# Altium schematic sheet MiniRoverShield.SchDoc
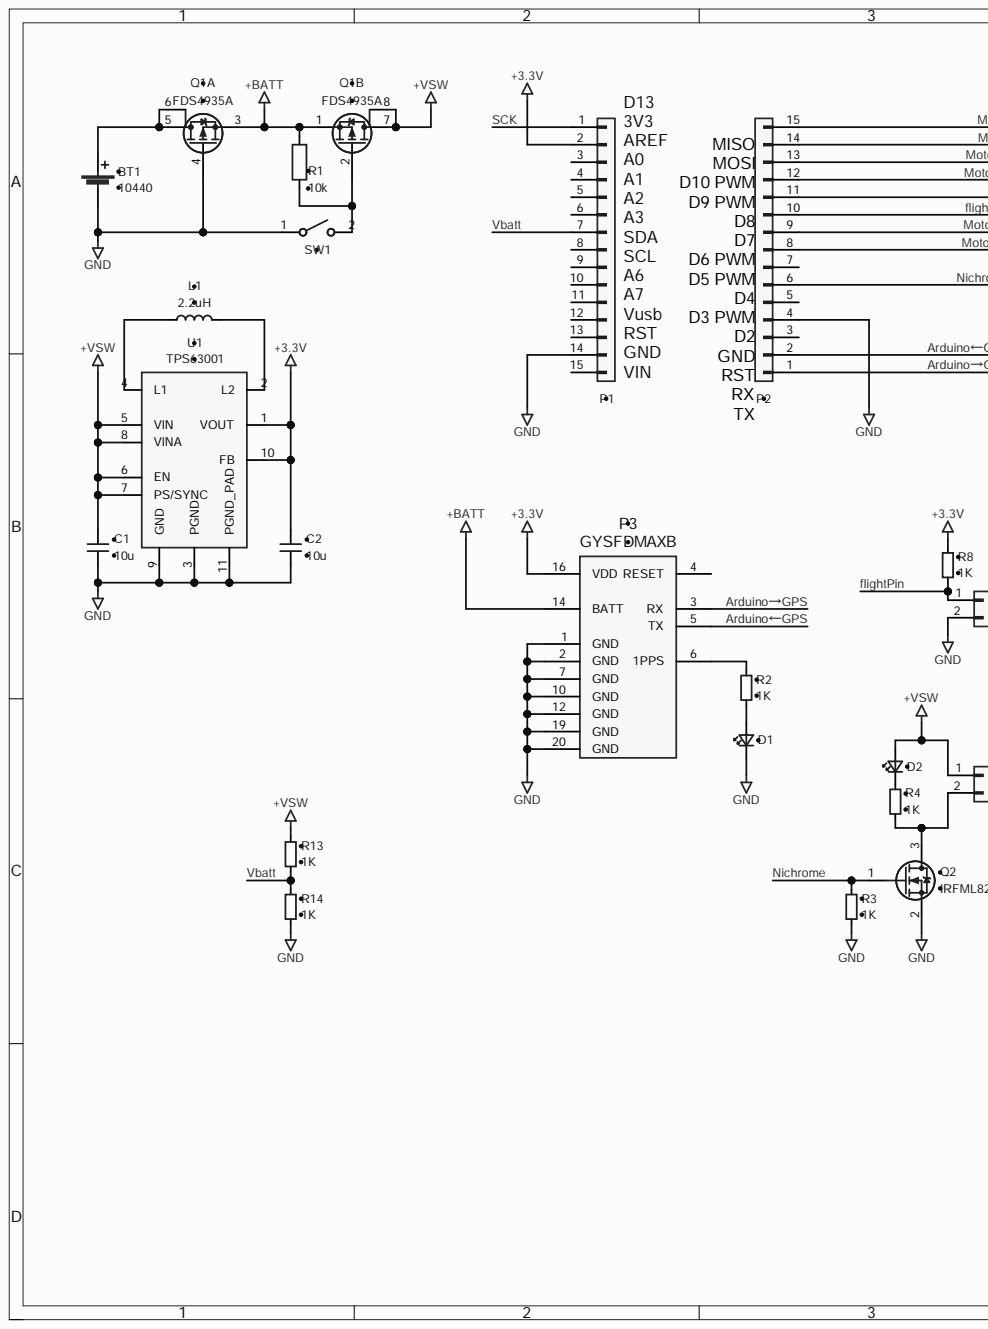
[diagram p0: MiniRoverShield.SchDoc, left]
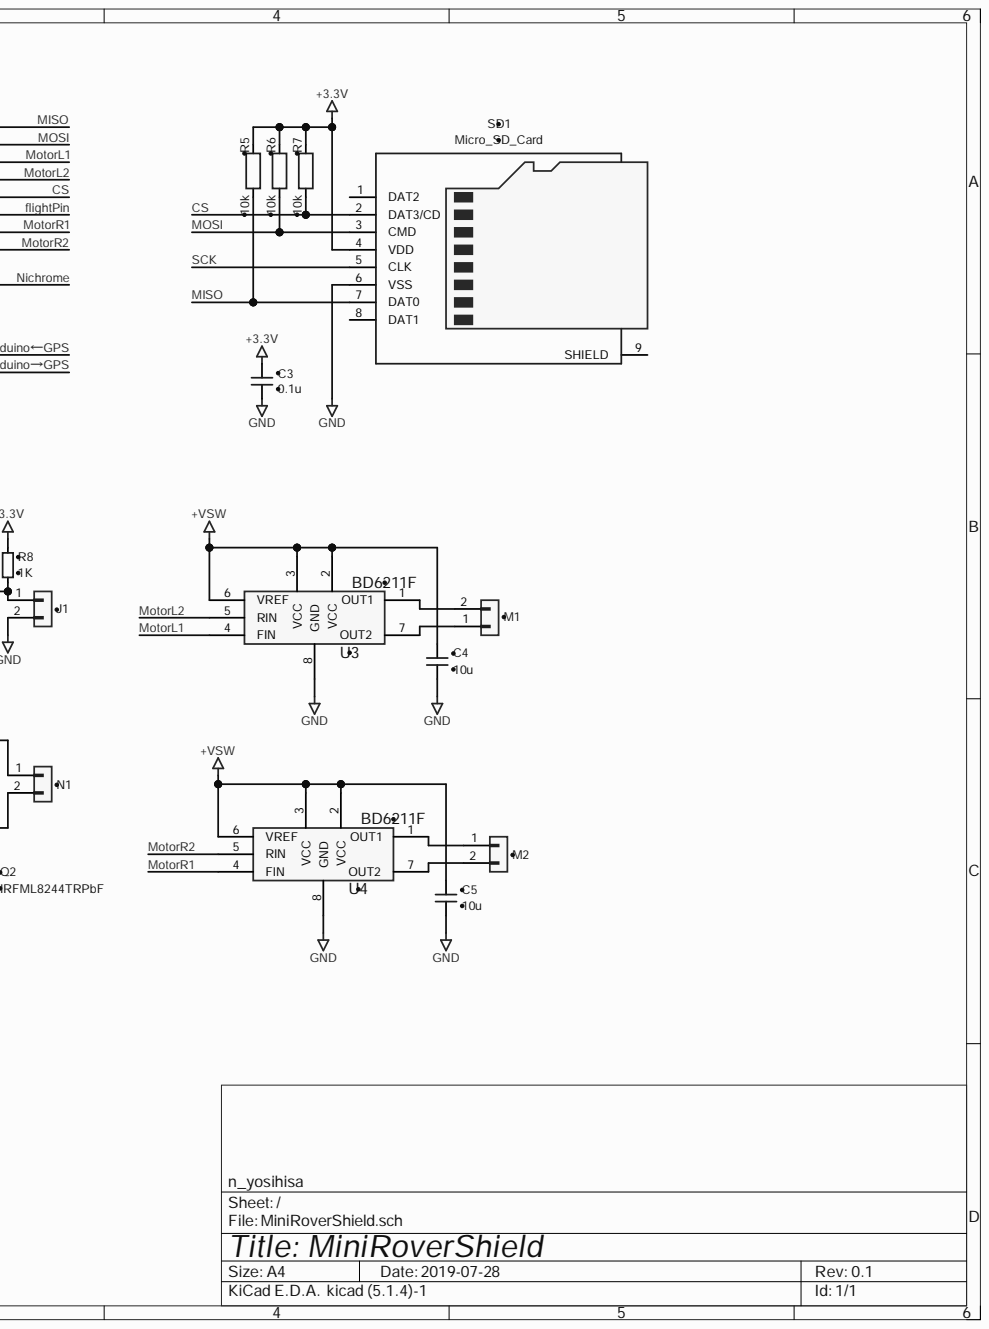
[diagram p1: MiniRoverShield.SchDoc, right]

## Parts
BT1  10440  [user:BC-0402_AAA]
C1  10u  [Capacitor_SMD:C_0805_2012Metric_Pad1.15x1.40mm_HandSolder]
C2  10u  [Capacitor_SMD:C_0805_2012Metric_Pad1.15x1.40mm_HandSolder]
C3  0.1u  [Capacitor_SMD:C_0603_1608Metric_Pad1.05x0.95mm_HandSolder]
C4  10u  [Capacitor_SMD:C_0805_2012Metric_Pad1.15x1.40mm_HandSolder]
C5  10u  [Capacitor_SMD:C_0805_2012Metric_Pad1.15x1.40mm_HandSolder]
D1  RED  [LED_SMD:LED_0603_1608Metric_Castellated]  (value not drawn)
D2  RED  [LED_SMD:LED_0603_1608Metric_Castellated]  (value not drawn)
J1  flightPin  [Connector_PinHeader_2.54mm:PinHeader_1x02_P2.54mm_Horizontal]
L1  2.2uH  [user_library:TDK_VLS3012]
M1  Motor  [Connector_JST:JST_EH_B02B-EH-A_1x02_P2.50mm_Vertical]  (value not drawn)
M2  Motor  [Connector_JST:JST_EH_B02B-EH-A_1x02_P2.50mm_Vertical]  (value not drawn)
N1  Nichrome  [user:MPT0.5_2-2.54]
P1  Arduino nano 33  [Connector_PinSocket_2.54mm:PinSocket_1x15_P2.54mm_Vertical]  (value not drawn)
P2  Arduino nano 33  [Connector_PinSocket_2.54mm:PinSocket_1x15_P2.54mm_Vertical]  (value not drawn)
P3  GYSFDMAXB  [user:GYSFDMAXB]
Q1  FDS4935A  [Package_SO:SOIC-8_3.9x4.9mm_P1.27mm]  (parts A,B)
Q2  IRFML8244TRPbF  [Package_TO_SOT_SMD:SOT-23]
R1  10k  [Resistor_SMD:R_0603_1608Metric_Pad1.05x0.95mm_HandSolder]
R2  1K  [Resistor_SMD:R_0603_1608Metric_Pad1.05x0.95mm_HandSolder]
R3  1K  [Resistor_SMD:R_0603_1608Metric_Pad1.05x0.95mm_HandSolder]
R4  1K  [Resistor_SMD:R_0603_1608Metric_Pad1.05x0.95mm_HandSolder]
R5  10k  [Resistor_SMD:R_0603_1608Metric_Pad1.05x0.95mm_HandSolder]
R6  10k  [Resistor_SMD:R_0603_1608Metric_Pad1.05x0.95mm_HandSolder]
R7  10k  [Resistor_SMD:R_0603_1608Metric_Pad1.05x0.95mm_HandSolder]
R8  1K  [Resistor_SMD:R_0603_1608Metric_Pad1.05x0.95mm_HandSolder]
R13  1K  [Resistor_SMD:R_0603_1608Metric_Pad1.05x0.95mm_HandSolder]
R14  1K  [Resistor_SMD:R_0603_1608Metric_Pad1.05x0.95mm_HandSolder]
SD1  Micro_SD_Card  [Connector_Card:microSD_HC_Hirose_DM3AT-SF-PEJM5]
SW1  Power  [user:SS-12D01-VG4]  (value not drawn)
U1  TPS63001  [Package_SON:Texas_S-PVSON-N10_ThermalVias]
U3  BD6211F  [Package_SO:SOIC-8_3.9x4.9mm_P1.27mm]
U4  BD6211F  [Package_SO:SOIC-8_3.9x4.9mm_P1.27mm]

## Nets
+3.3V: C2.1 C3.1 P1.2 P3.16(VDD) R5.1 R6.1 R7.1 R8.1 SD1.4(VDD) U1.1(VOUT) U1.10(FB)
+BATT: P3.14(BATT) Q1.1 Q1.3 R1.1
+VSW: C1.1 C4.1 C5.1 D2.2 N1.1 Q1.7 Q1.8 R13.1 U1.5(VIN) U1.6(EN) U1.7(PS/SYNC) U1.8(VINA) U3.2(VCC) U3.3(VCC) U3.6(VREF) U4.2(VCC) U4.3(VCC) U4.6(VREF)
GND: BT1.2 C1.2 C2.2 C3.2 C4.2 C5.2 D1.1 J1.2 P1.14 P2.4 P3.1(GND) P3.2(GND) P3.7(GND) P3.10(GND) P3.12(GND) P3.19(GND) P3.20(GND) Q1.4 Q2.2 R3.2 R14.2 SD1.6(VSS) SW1.1 U1.3(PGND) U1.9(GND) U1.11(PGND_PAD) U3.8(GND) U4.8(GND)
Arduino←GPS: P2.2 P3.5(TX)
Arduino→GPS: P2.1 P3.3(RX)
CS: P2.11 R7.2 SD1.2(DAT3/CD)
flightPin: J1.1 P2.10 R8.2
MISO: P2.15 R5.2 SD1.7(DAT0)
MOSI: P2.14 R6.2 SD1.3(CMD)
MotorL1: P2.13 U3.4(FIN)
MotorL2: P2.12 U3.5(RIN)
MotorR1: P2.9 U4.4(FIN)
MotorR2: P2.8 U4.5(RIN)
Nichrome: P2.6 Q2.1 R3.1
SCK: P1.1 SD1.5(CLK)
Vbatt: P1.7 R13.2 R14.1
NetBT1_1: BT1.1 Q1.5 Q1.6
NetD1_2: D1.2 R2.2
NetD2_1: D2.1 R4.1
NetL1_1: L1.1 U1.4(L1)
NetL1_2: L1.2 U1.2(L2)
NetM1_1: M1.1 U3.7(OUT2)
NetM1_2: M1.2 U3.1(OUT1)
NetM2_1: M2.1 U4.1(OUT1)
NetM2_2: M2.2 U4.7(OUT2)
NetN1_2: N1.2 Q2.3 R4.2
NetP3_6: P3.6(1PPS) R2.1
NetQ1_2: Q1.2 R1.2 SW1.2

Not connected: P1 3 4 5 6 8 9 10 11 12 13 15; P2 3 5 7; P3 4(RESET); SD1 1(DAT2) 8(DAT1) 9(SHIELD)

## Library data of the parts
BT1 = Battery_Cell: Component Kind: Standard; Library Name: Device; Value: 10440
C1-C5 = C_Small: Component Kind: Standard; Library Name: Device
  C1 C2 C4 C5: Value: 10u
  C3: Value: 0.1u
D1 D2 = LED_Small: Component Kind: Standard; Library Name: Device; Value: RED
J1 M1 M2 N1 = Conn_01x02: Component Kind: Standard; Library Name: Connector_Generic
  J1: Value: flightPin
  M1 M2: Value: Motor
  N1: Value: Nichrome
L1 = L: Component Kind: Standard; Library Name: Device; Value: 2.2uH
P1 P2 = Conn_01x15: Component Kind: Standard; Library Name: Connector_Generic; Value: Arduino nano 33
P3 = GYSFDMAXB-user_library: Component Kind: Standard; Value: GYSFDMAXB; Library Name: MiniRoverShield-rescue
Q1 = FDS4935A-user_library: Component Kind: Standard; Value: FDS4935A; Library Name: MiniRoverShield-rescue
Q2 = Q_NMOS_GSD: Component Kind: Standard; Library Name: Device; Value: IRFML8244TRPbF
R1 R5-R7 = R: Component Kind: Standard; Library Name: Device; Value: 10k
R2-R4 R8 R13 R14 = R_Small: Component Kind: Standard; Library Name: Device; Value: 1K
SD1 = Micro_SD_Card-Connector: Component Kind: Standard; Value: Micro_SD_Card; Library Name: MiniRoverShield-rescue
SW1 = SW_SPST: Component Kind: Standard; Library Name: Switch; Value: Power
U1 = TPS63000-user_library: Component Kind: Standard; Value: TPS63001; Library Name: MiniRoverShield-rescue
U3 U4 = BD6231F_2-user_library: Component Kind: Standard; Value: BD6211F; Library Name: MiniRoverShield-rescue
